# Altium schematic sheet Power Supply1.SchDoc("Power Supply1")
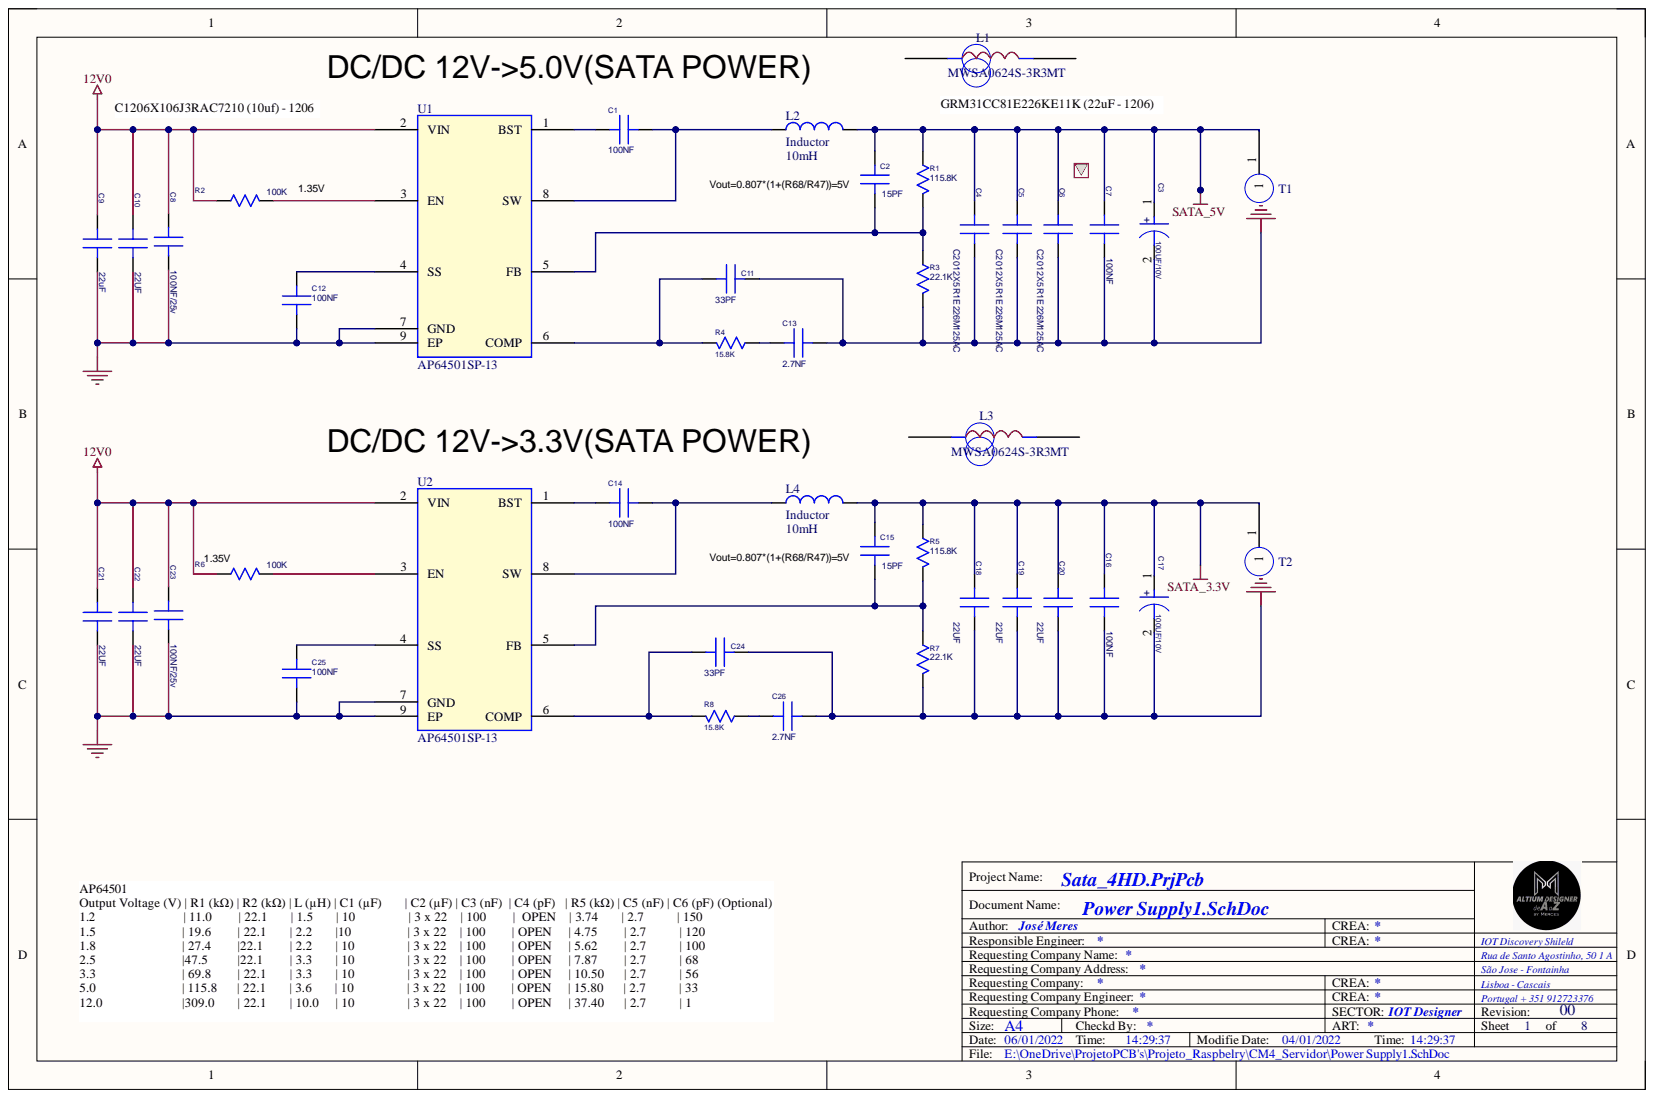
[diagram p0: Power Supply1.SchDoc]

## Parts
C1  100NF  [C0402-ROUND]
C2  15PF  [C0402-ROUND]
C3  100UF/10V  [Capacitor SMD Eletrolitic-D8.0x10]
C4  C2012X5R1E226M125AC  [C0805-ROUND]
C5  C2012X5R1E226M125AC  [C0805-ROUND]
C6  C2012X5R1E226M125AC  [C0805-ROUND]
C7  100NF  [C0402-ROUND]
C8  100NF/25v  [C0805-ROUND]
C9  22uF  [C0805-ROUND]
C10  22UF  [C0805-ROUND]
C11  33PF  [C0402-ROUND]
C12  100NF  [C0402-ROUND]
C13  2.7NF  [C0402-ROUND]
C14  100NF  [C0402-ROUND]
C15  15PF  [C0402-ROUND]
C16  100NF  [C0402-ROUND]
C17  100UF/10V  [Capacitor SMD Eletrolitic-D8.0x10]
C18  22UF  [C0805-ROUND]
C19  22UF  [C0805-ROUND]
C20  22UF  [C0805-ROUND]
C21  22UF  [C0805-ROUND]
C22  22UF  [C0805-ROUND]
C23  100NF/25v  [C0805-ROUND]
C24  33PF  [C0402-ROUND]
C25  100NF  [C0402-ROUND]
C26  2.7NF  [C0402-ROUND]
L1  MWSA0624S-3R3MT  [NRS3015T2R2MNGHV]
L2  Inductor  value 10mH  [NR6045-220M]
L3  MWSA0624S-3R3MT  [NRS3015T2R2MNGHV]
L4  Inductor  value 10mH  [NR6045-220M]
R1  115.8K  [R0402-ROUND]
R2  100K  [R0402-ROUND]
R3  22.1K  [R0402-ROUND]
R4  15.8K  [R0402-ROUND]
R5  115.8K  [R0402-ROUND]
R6  100K  [R0402-ROUND]
R7  22.1K  [R0402-ROUND]
R8  15.8K  [R0402-ROUND]
T1  TEST  [TEST_40]  (value not drawn)
T2  TEST  [TEST_40]  (value not drawn)
U1  AP64501SP-13  [SO8-EP]
U2  AP64501SP-13  [SO8-EP]

## Nets
12V0: C8.1 C9.1 C10.1 C21.1 C22.1 C23.1 R2.1 R6.1 U1.2(VIN) U2.2(VIN)
GND: C3.2 C4.2 C5.2 C6.2 C7.2 C8.2 C9.2 C10.2 C11.2 C12.2 C13.2 C16.2 C17.2 C18.2 C19.2 C20.2 C21.2 C22.2 C23.2 C24.2 C25.2 C26.2 R3.2 R7.2 U1.7(GND) U1.9(EP) U2.7(GND) U2.9(EP)
SATA_3.3V: C15.1 C16.1 C17.1 C18.1 C19.1 C20.1 L4.2 R5.1 T2.1
SATA_5V: C2.1 C3.1 C4.1 C5.1 C6.1 C7.1 L2.2 R1.1 T1.1
NetC1_1: C1.1 U1.1(BST)
NetC1_2: C1.2 L2.1 U1.8(SW)
NetC2_2: C2.2 R1.2 R3.1 U1.5(FB)
NetC11_1: C11.1 R4.2 U1.6(COMP)
NetC12_1: C12.1 U1.4(SS)
NetC13_1: C13.1 R4.1
NetC14_1: C14.1 U2.1(BST)
NetC14_2: C14.2 L4.1 U2.8(SW)
NetC15_2: C15.2 R5.2 R7.1 U2.5(FB)
NetC24_1: C24.1 R8.2 U2.6(COMP)
NetC25_1: C25.1 U2.4(SS)
NetC26_1: C26.1 R8.1
NetR2_2: R2.2 U1.3(EN)
NetR6_2: R6.2 U2.3(EN)

Not connected: L1 1 2; L3 1 2

## Library data of the parts
C1 C11-C14 C24-C26 = C_0_Dup1: PARTS: 1; %182%%238%%182%%168%%185%%164%%215%%247%%181%%231%%209%%185%(V): 16; %195%%232%%202%%246%: SMD陶瓷电容-16V-100NF-+/-10%-X7R-0402-BT; %208%%161%%192%%224%%195%%251%%179%%198%: SMD陶瓷电容; CODE: 8070614; COMPONENT_HIGH_MAX: 0.55MM; DEVICE: RMEMK105BJ104KV_FR; LEVEL: STD; OriginalSymbolOrigin: (0,30); PCB Footprint: SC0402; PINSWAP: (A,B); Component Kind: Standard; PKG_TYPE: SC0402
C2 C15 = C_0_Dup1: PARTS: 1; %182%%238%%182%%168%%185%%164%%215%%247%%181%%231%%209%%185%(V): 50; %195%%232%%202%%246%: SMD陶瓷电容-50V-0.015NF-+/-5%-NP0-0402; %208%%161%%192%%224%%195%%251%%179%%198%: SMD陶瓷电容; CODE: 8070544; COMPONENT_HIGH_MAX: 0.55MM; DEVICE: C0402C150J5GAC_7867; LEVEL: STD; OriginalSymbolOrigin: (0,30); PCB Footprint: SC0402; PINSWAP: (A,B); Component Kind: Standard; PKG_TYPE: SC0402
C3 C17 = CP_0: PARTS: 1; %182%%238%%182%%168%%185%%164%%215%%247%%181%%231%%209%%185%(V): 25; %195%%232%%202%%246%: 非固体SMD铝电容-25V-220UF-+/-20%-8*10.5-8.3*8.3MM-极性-105DEGC-1000H-SMD-温度敏感器件-工艺耐温250DEGC,5S; %208%%161%%192%%224%%195%%251%%179%%198%: 非固体SMD铝电容; CODE: 8120004; COMPONENT_HIGH_MAX: 10.5MM; DEVICE: EMVE250ADA221MHA0G; LEVEL: STD; OriginalSymbolOrigin: (0,30); PCB Footprint: ct7343; PKG_TYPE: SCAPC2-280-327; Component Kind: Standard
C4-C6 C18-C20 = C_0_Dup1: PARTS: 1; %182%%238%%182%%168%%185%%164%%215%%247%%181%%231%%209%%185%(V): 6.3; %195%%232%%202%%246%: SMD陶瓷电容-6.3V-47000NF-+/-20%-X6S-1206-供电专用; %208%%161%%192%%224%%195%%251%%179%%198%: SMD陶瓷电容; CODE: 8071639; COMPONENT_HIGH_MAX: 1.8MM; DEVICE: C3216X6S0J476MTJ00N; LEVEL: STD; OriginalSymbolOrigin: (0,30); PCB Footprint: SC0805; PINSWAP: (A,B); Component Kind: Standard; PKG_TYPE: SC0805
C7 C8 C16 C23 = C_0_Dup1: PARTS: 1; %182%%238%%182%%168%%185%%164%%215%%247%%181%%231%%209%%185%(V): 50; %195%%232%%202%%246%: SMD陶瓷电容-50V-100NF-+/-10%-X7R-0603; %208%%161%%192%%224%%195%%251%%179%%198%: SMD陶瓷电容; CODE: 8070627; COMPONENT_HIGH_MAX: 0.9MM; DEVICE: C1608X7R1H104KT000N; LEVEL: STD; OriginalSymbolOrigin: (0,30); PCB Footprint: SC0402; PINSWAP: (A,B); PKG_TYPE: SC0603; Component Kind: Standard
C9 C10 C21 C22 = C_0_Dup1: PARTS: 1; %182%%238%%182%%168%%185%%164%%215%%247%%181%%231%%209%%185%(V): 25; %195%%232%%202%%246%: SMD陶瓷电容-25V-22000NF-+/-10%-X7R-1210-所有; %208%%161%%192%%224%%195%%251%%179%%198%: SMD陶瓷电容; CODE: 8070825; COMPONENT_HIGH_MAX: 2.7MM; DEVICE: CETMK325B7226KM_T; LEVEL: STD; OriginalSymbolOrigin: (0,30); PCB Footprint: SC1206; PINSWAP: (A,B); Component Kind: Standard; PKG_TYPE: SC1206
L1 L3 = SWPA4030S: 贴片箌率电感; PCB Footprint: SPL0707G; SIGNAL_MODEL: DEFAULT_INDUCTOR_1e+010nH_1_2; Component Kind: Standard
L2 L4 = Inductor: Code_IEC: 1005; Code_JEITA: 0402; Component Kind: Standard; Description: Inductor; LatestRevisionDate: 17-Jul-2002; LatestRevisionNote: Re-released for DXP Platform.; PackageReference: 0402-A; Published: 8-Jun-2000; Signal Integrity: Inductor; Simulation: INDUCTOR; Library Name: Merces_Library.SchLib; Publisher: Altium Limited
R1 R5 = R_0_Dup1: PARTS: 1; %182%%238%%182%%168%%185%%166%%194%%202%(W): 0.1; %195%%232%%202%%246%: 片式厚膜电阻器-0.1W-33200OHM-+/-1%-0603; %208%%161%%192%%224%%195%%251%%179%%198%: 片式厚膜电阻器; %215%%232%%214%%181%%212%%202%%208%%237%%198%%171%%178%%238%: +/-1%; CODE: 7090766; COMPONENT_HIGH_MAX: 0.55MM; DEVICE: RC0603FR_0733K2; LEVEL: STD; OriginalSymbolOrigin: (10,20); PCB Footprint: SR0402; PINSWAP: (A,B); Component Kind: Standard; PKG_TYPE: SR0402
R2 R6 = R_0_Dup1: PARTS: 1; %182%%238%%182%%168%%185%%166%%194%%202%(W): 0.06; %195%%232%%202%%246%: 片式厚膜电阻器-0.0625W-100000OHM-+/-5%-0402-BT; %208%%161%%192%%224%%195%%251%%179%%198%: 片式厚膜电阻器; %215%%232%%214%%181%%212%%202%%208%%237%%198%%171%%178%%238%: +/-5%; CODE: 7090831; COMPONENT_HIGH_MAX: 0.37MM; DEVICE: RK73B1ELTP104J; LEVEL: STD; OriginalSymbolOrigin: (10,20); PCB Footprint: SR0402; PINSWAP: (A,B); Component Kind: Standard; PKG_TYPE: SR0402
R3 R7 = R_0_Dup1: PARTS: 1; %182%%238%%182%%168%%185%%166%%194%%202%(W): 0.1; %195%%232%%202%%246%: 片式厚膜电阻器-0.1W-10700OHM-+/-1%-0603; %208%%161%%192%%224%%195%%251%%179%%198%: 片式厚膜电阻器; %215%%232%%214%%181%%212%%202%%208%%237%%198%%171%%178%%238%: +/-1%; CODE: 7090969; COMPONENT_HIGH_MAX: 0.55MM; DEVICE: RC0603FR_0710K7; LEVEL: STD; OriginalSymbolOrigin: (10,20); PCB Footprint: SR0402; PINSWAP: (A,B); PKG_TYPE: SR0603; Component Kind: Standard
R4 R8 = R_0_Dup2: PARTS: 1; %182%%238%%182%%168%%185%%166%%194%%202%(W): 0.1; %195%%232%%202%%246%: 片式厚膜电阻器-0.1W-40200ohm-+/-1%-0603; %208%%161%%192%%224%%195%%251%%179%%198%: 片式厚膜电阻器; %215%%232%%214%%181%%212%%202%%208%%237%%198%%171%%178%%238%: +/-1%; CODE: 7090935; COMPONENT_HIGH_MAX: 0.55mm; DEVICE: RK73H1JTTD4022F; LEVEL: STD; OriginalSymbolOrigin: (10,20); PCB Footprint: SR0402; PINSWAP: (A,B); PKG_TYPE: SR0603; Component Kind: Standard
T1 T2 = TEST: PCB Footprint: tp_40; Component Kind: Standard
U1 U2 = AP64501SP-13: PCB Footprint: SO8EP_P1D27_W4; Component Kind: Standard; Library Name: Merces_Library.SchLib
